# Altium schematic sheet ESP-WROOM32.SchDoc(ESP-WROOM32) (continued)

## Library data of the parts
*1 = ESP32-WROOM-32E-N4: RF TXRX MOD BT WIFI TH SMD; Manufacturer: Espressif Systems; Max Supply Voltage: 3.6V; Min Supply Voltage: 3V; Operating Supply Voltage: 3.3V; Category: System on Chip (SoC); Component Kind: Standard; ComponentLink1Description: https://datasheet.octopart.com:443/ESP32-WROOM-32E-N4-Espressif-Systems-datasheet-182247776.pdf?src-supplier=IHS; ComponentLink1URL: https://datasheet.octopart.com:443/ESP32-WROOM-32E-N4-Espressif-Systems-datasheet-182247776.pdf?src-supplier=IHS; ComponentLink2Description: https://datasheet.octopart.com:443/ESP32-WROOM-32E-N4-Espressif-Systems-datasheet-188867343.pdf?src-supplier=TME; ComponentLink2URL: https://datasheet.octopart.com:443/ESP32-WROOM-32E-N4-Espressif-Systems-datasheet-188867343.pdf?src-supplier=TME; ComponentLink3Description: https://datasheet.octopart.com:443/ESP32-WROOM-32E-N4-Espressif-Systems-datasheet-165560559.pdf?src-supplier=TME; ComponentLink3URL: https://datasheet.octopart.com:443/ESP32-WROOM-32E-N4-Espressif-Systems-datasheet-165560559.pdf?src-supplier=TME; ComponentLink4Description: https://datasheet.octopart.com:443/ESP32-WROOM-32E-N4-Espressif-Systems-datasheet-151884363.pdf?src-supplier=Future+Electronics; ComponentLink4URL: https://datasheet.octopart.com:443/ESP32-WROOM-32E-N4-Espressif-Systems-datasheet-151884363.pdf?src-supplier=Future+Electronics; Height - Seated (Max): 3.25mm; LCSC part number: C701341; Length: 25.5mm; Manufacturer Part Number: ESP32-WROOM-32E-N4; Max Operating Temperature: 85°C; Min Operating Temperature: -40°C; Number of Terminals: 38; Pricing: 1=4.84, 10=4.189, 25=3.9612, 100=3.649, 250=3.46184 (USD); RoHS: Compliant; Stock: 16186; Supplier: DigiKey; Supplier Part Number: 1965-ESP32-WROOM-32E-N4CT-ND; Terminal Pitch: 1.27mm; Width: 18mm; Library Name: Librayryschematic.SchLib
C1 C2 C5-C8 C12 = C1206C104K5JACAUTO: CAP CER 0.1UF 50V U2J 1206; LSCS PART NUMBER: C2264143; Manufacturer: Samsung Electro-Mechanics; Manufacturer Part Number: CL05B104KB5NNWC; Dielectric Material: Ceramic; Value: 100nF; Case/Package: 1206; Category: Ceramic Capacitors; China RoHS: Compliant; Component Kind: Standard; ComponentLink1Description: https://datasheet.octopart.com:443/CL05B104KB5NNWC-Samsung-Electro-Mechanics-datasheet-182018729.pdf?src-supplier=IHS; ComponentLink1URL: https://datasheet.octopart.com:443/CL05B104KB5NNWC-Samsung-Electro-Mechanics-datasheet-182018729.pdf?src-supplier=IHS; ComponentLink2Description: https://datasheet.octopart.com:443/C1206C104K5JACAUTO-KEMET-datasheet-175574894.pdf?src-supplier=IHS; ComponentLink2URL: https://datasheet.octopart.com:443/C1206C104K5JACAUTO-KEMET-datasheet-175574894.pdf?src-supplier=IHS; ComponentLink3Description: https://datasheet.octopart.com:443/C1206C104K5JACAUTO-KEMET-datasheet-138896532.pdf?src-supplier=Upverter; ComponentLink3URL: https://datasheet.octopart.com:443/C1206C104K5JACAUTO-KEMET-datasheet-138896532.pdf?src-supplier=Upverter; ComponentLink4Description: https://datasheet.octopart.com:443/C1206C104K5JACAUTO-KEMET-datasheet-141421995.pdf?src-supplier=Farnell; ComponentLink4URL: https://datasheet.octopart.com:443/C1206C104K5JACAUTO-KEMET-datasheet-141421995.pdf?src-supplier=Farnell; ComponentLink5Description: https://datasheet.octopart.com:443/C1206C104K5JACAUTO-KEMET-datasheet-110724407.pdf?src-supplier=RS+(Formerly+Allied+Electronics); ComponentLink5URL: https://datasheet.octopart.com:443/C1206C104K5JACAUTO-KEMET-datasheet-110724407.pdf?src-supplier=RS+(Formerly+Allied+Electronics); Dielectric: U2J; Height: 1.2mm; LCSC part number: C7229230; Length: 3.2mm; Lifecycle Status: Production; Material: Ceramic; Max Operating Temperature: 125°C; Min Operating Temperature: -55°C; Mounting Technology: Surface Mount; Number of Terminals: 2; Pricing: 1=0.1, 10=0.054, 50=0.037, 100=0.0319, 500=0.02338, 1000=0.02075, 2500=0.018, 5000=0.01634 (USD); REACH SVHC: Yes; RoHS: Compliant; Schedule B: 8532240020, 8532240020|8532240020|8532240020|8532240020|8532240020; Stock: 89724; Supplier: DigiKey; Supplier Part Number: 1276-CL05B104KB5NNWCCT-ND; Voltage Rating: 50V; Voltage Rating (DC): 50V; Width: 1.6mm; Library Name: Librayryschematic.SchLib
C3 C9 C10 = CC1206MKX5R6BB226: CAP CER 22UF 10V X5R 1206; LSCS PART NUMBER: C723738; Manufacturer: Murata; Manufacturer Lifecycle Status: IN PRODUCTION; Manufacturer Part Number: GRM158R61A226ME15D; Dielectric Material: Ceramic; Value: 22µF; Case Code (Imperial): 1206; Case Code (Metric): 3216; Case/Package: 0402; Category: Ceramic Capacitors; China RoHS: Compliant; Component Kind: Standard; ComponentLink1Description: https://datasheet.octopart.com:443/GRM158R61A226ME15D-Murata-datasheet-165579666.pdf?src-supplier=TME; ComponentLink1URL: https://datasheet.octopart.com:443/GRM158R61A226ME15D-Murata-datasheet-165579666.pdf?src-supplier=TME; ComponentLink2Description: https://datasheet.octopart.com/GRM158R61A226ME15D-Murata-datasheet-12515295.pdf; ComponentLink2URL: https://datasheet.octopart.com/GRM158R61A226ME15D-Murata-datasheet-12515295.pdf; ComponentLink3Description: https://datasheet.octopart.com/GRM158R61A226ME15D-Murata-datasheet-12510076.pdf; ComponentLink3URL: https://datasheet.octopart.com/GRM158R61A226ME15D-Murata-datasheet-12510076.pdf; ComponentLink4Description: https://datasheet.octopart.com/GRM158R61A226ME15D-Murata-datasheet-11978611.pdf; ComponentLink4URL: https://datasheet.octopart.com/GRM158R61A226ME15D-Murata-datasheet-11978611.pdf; ComponentLink5Description: https://datasheet.octopart.com/GRM158R61A226ME15D-Murata-datasheet-10025737.pdf; ComponentLink5URL: https://datasheet.octopart.com/GRM158R61A226ME15D-Murata-datasheet-10025737.pdf; ComponentLink6Description: https://datasheet.octopart.com/GRM158R61A226ME15D-Murata-datasheet-8819587.pdf; ComponentLink6URL: https://datasheet.octopart.com/GRM158R61A226ME15D-Murata-datasheet-8819587.pdf; ComponentLink7Description: http://sigma.octopart.com:80/reach_statement/Murata-company-16.pdf?src-supplier=Compliance+Statements; ComponentLink7URL: http://sigma.octopart.com:80/reach_statement/Murata-company-16.pdf?src-supplier=Compliance+Statements; ComponentLink8Description: https://datasheet.octopart.com:443/CC1206MKX5R6BB226-Yageo-datasheet-170350636.pdf?src-supplier=IHS; ComponentLink8URL: https://datasheet.octopart.com:443/CC1206MKX5R6BB226-Yageo-datasheet-170350636.pdf?src-supplier=IHS; ComponentLink9Description: https://datasheet.octopart.com:443/CC1206MKX5R6BB226-Yageo-datasheet-182965674.pdf?src-supplier=Farnell; ComponentLink9URL: https://datasheet.octopart.com:443/CC1206MKX5R6BB226-Yageo-datasheet-182965674.pdf?src-supplier=Farnell; ComponentLink10Description: https://datasheet.octopart.com:443/CC1206MKX5R6BB226-Yageo-Group-datasheet-145016144.pdf?src-supplier=ODG+(Origin+Data+Global); ComponentLink10URL: https://datasheet.octopart.com:443/CC1206MKX5R6BB226-Yageo-Group-datasheet-145016144.pdf?src-supplier=ODG+(Origin+Data+Global); ComponentLink11Description: https://datasheet.octopart.com:443/CC1206MKX5R6BB226-Yageo-datasheet-137700085.pdf?src-supplier=Future+Electronics; ComponentLink11URL: https://datasheet.octopart.com:443/CC1206MKX5R6BB226-Yageo-datasheet-137700085.pdf?src-supplier=Future+Electronics; ComponentLink12Description: https://datasheet.octopart.com:443/CC1206MKX5R6BB226-Yageo-datasheet-82288757.pdf?src-supplier=Future+Electronics; ComponentLink12URL: https://datasheet.octopart.com:443/CC1206MKX5R6BB226-Yageo-datasheet-82288757.pdf?src-supplier=Future+Electronics; ComponentLink13Description: https://datasheet.octopart.com:443/CC1206MKX5R6BB226-Yageo-datasheet-78855685.pdf?src-supplier=Future+Electronics; ComponentLink13URL: https://datasheet.octopart.com:443/CC1206MKX5R6BB226-Yageo-datasheet-78855685.pdf?src-supplier=Future+Electronics; ComponentLink14Description: https://datasheet.octopart.com:443/CC1206MKX5R6BB226-Yageo-datasheet-62336348.pdf?src-supplier=Future+Electronics; ComponentLink14URL: https://datasheet.octopart.com:443/CC1206MKX5R6BB226-Yageo-datasheet-62336348.pdf?src-supplier=Future+Electronics; ComponentLink15Description: https://datasheet.octopart.com:443/CC1206MKX5R6BB226-Yageo-datasheet-68298032.pdf?src-supplier=Future+Electronics; ComponentLink15URL: https://datasheet.octopart.com:443/CC1206MKX5R6BB226-Yageo-datasheet-68298032.pdf?src-supplier=Future+Electronics; ComponentLink16Description: https://datasheet.octopart.com/CC1206MKX5R6BB226-Yageo-datasheet-86397281.pdf; ComponentLink16URL: https://datasheet.octopart.com/CC1206MKX5R6BB226-Yageo-datasheet-86397281.pdf; ComponentLink17Description: http://sigma.octopart.com:80/rohs_statement/Yageo-company-2.pdf?src-supplier=Compliance+Statements; ComponentLink17URL: http://sigma.octopart.com:80/rohs_statement/Yageo-company-2.pdf?src-supplier=Compliance+Statements; ComponentLink18Description: http://sigma.octopart.com:80/conflict_mineral_statement/Yageo-company-3.pdf?src-supplier=Compliance+Statements; ComponentLink18URL: http://sigma.octopart.com:80/conflict_mineral_statement/Yageo-company-3.pdf?src-supplier=Compliance+Statements; Dielectric: X5R; Halogen Free: Halogen Free; Height: 800µm; LCSC part number: C3845593; Lead Free: Lead Free; Length: 1mm; Lifecycle Status: Production; Material: Ceramic; Max Operating Temperature: 85°C; Min Operating Temperature: -55°C; Mounting Technology: Surface Mount; Number of Terminals: 2; Pricing: 1=0.41, 10=0.247, 50=0.1828, 100=0.1632, 500=0.1301, 1000=0.1199, 2500=0.10912 (USD); REACH SVHC: Yes; RoHS: Compliant; Schedule B: 8532240020, 8532240020|8532240020|8532240020|8532240020|8532240020; Stock: 340623; Supplier: DigiKey; Supplier Part Number: 490-GRM158R61A226ME15DCT-ND; Thickness: 800µm; Tolerance: 20%; Voltage Rating: 10V; Voltage Rating (DC): 10V; Width: 500µm; Library Name: Librayryschematic.SchLib
C4 C11 = CC1206KKX5R6BB106: CAP CER 10UF 10V X5R 1206; LSCS PART NUMBER: C527332; Manufacturer: Yageo Group; Manufacturer Part Number: CC0402MRX5R6BB106; Dielectric Material: Ceramic; Tolerance: 10%; Value: 10µF; Case Code (Imperial): 1206; Case Code (Metric): 3216; Case/Package: 0402; Category: Ceramic Capacitors; China RoHS: Compliant; Component Kind: Standard; ComponentLink1Description: https://datasheet.octopart.com:443/CC0402MRX5R6BB106-Yageo-datasheet-170350636.pdf?src-supplier=IHS; ComponentLink1URL: https://datasheet.octopart.com:443/CC0402MRX5R6BB106-Yageo-datasheet-170350636.pdf?src-supplier=IHS; ComponentLink2Description: https://datasheet.octopart.com:443/CC0402MRX5R6BB106-Yageo-Group-datasheet-189192896.pdf?src-supplier=TME; ComponentLink2URL: https://datasheet.octopart.com:443/CC0402MRX5R6BB106-Yageo-Group-datasheet-189192896.pdf?src-supplier=TME; ComponentLink3Description: https://datasheet.octopart.com:443/CC0402MRX5R6BB106-Yageo-datasheet-182029486.pdf?src-supplier=Newark; ComponentLink3URL: https://datasheet.octopart.com:443/CC0402MRX5R6BB106-Yageo-datasheet-182029486.pdf?src-supplier=Newark; ComponentLink4Description: https://datasheet.octopart.com:443/CC0402MRX5R6BB106-Yageo-datasheet-158238148.pdf?src-supplier=Newark; ComponentLink4URL: https://datasheet.octopart.com:443/CC0402MRX5R6BB106-Yageo-datasheet-158238148.pdf?src-supplier=Newark; ComponentLink5Description: https://datasheet.octopart.com/CC0402MRX5R6BB106-Yageo-datasheet-86397281.pdf; ComponentLink5URL: https://datasheet.octopart.com/CC0402MRX5R6BB106-Yageo-datasheet-86397281.pdf; ComponentLink6Description: https://datasheet.octopart.com:443/CC0402MRX5R6BB106-Yageo-datasheet-175963154.pdf?src-supplier=element14+APAC; ComponentLink6URL: https://datasheet.octopart.com:443/CC0402MRX5R6BB106-Yageo-datasheet-175963154.pdf?src-supplier=element14+APAC; ComponentLink7Description: https://datasheet.octopart.com:443/CC0402MRX5R6BB106-Yageo-Group-datasheet-145016144.pdf?src-supplier=ODG+(Origin+Data+Global); ComponentLink7URL: https://datasheet.octopart.com:443/CC0402MRX5R6BB106-Yageo-Group-datasheet-145016144.pdf?src-supplier=ODG+(Origin+Data+Global); ComponentLink8Description: https://datasheet.octopart.com:443/CC0402MRX5R6BB106-Yageo-datasheet-62067349.pdf?src-supplier=Future+Electronics; ComponentLink8URL: https://datasheet.octopart.com:443/CC0402MRX5R6BB106-Yageo-datasheet-62067349.pdf?src-supplier=Future+Electronics; ComponentLink9Description: http://sigma.octopart.com:80/conflict_mineral_statement/Yageo-company-3.pdf?src-supplier=Compliance+Statements; ComponentLink9URL: http://sigma.octopart.com:80/conflict_mineral_statement/Yageo-company-3.pdf?src-supplier=Compliance+Statements; ComponentLink10Description: http://sigma.octopart.com:80/rohs_statement/Yageo-company-2.pdf?src-supplier=Compliance+Statements; ComponentLink10URL: http://sigma.octopart.com:80/rohs_statement/Yageo-company-2.pdf?src-supplier=Compliance+Statements; ComponentLink11Description: https://datasheet.octopart.com:443/CC1206KKX5R6BB106-Yageo-datasheet-170350636.pdf?src-supplier=IHS; ComponentLink11URL: https://datasheet.octopart.com:443/CC1206KKX5R6BB106-Yageo-datasheet-170350636.pdf?src-supplier=IHS; ComponentLink12Description: https://datasheet.octopart.com:443/CC1206KKX5R6BB106-Yageo-datasheet-10911834.pdf?src-supplier=element14+APAC; ComponentLink12URL: https://datasheet.octopart.com:443/CC1206KKX5R6BB106-Yageo-datasheet-10911834.pdf?src-supplier=element14+APAC; ComponentLink13Description: https://datasheet.octopart.com:443/CC1206KKX5R6BB106-Yageo-datasheet-62067349.pdf?src-supplier=element14+APAC; ComponentLink13URL: https://datasheet.octopart.com:443/CC1206KKX5R6BB106-Yageo-datasheet-62067349.pdf?src-supplier=element14+APAC; ComponentLink14Description: https://datasheet.octopart.com:443/CC1206KKX5R6BB106-Yageo-datasheet-181970622.pdf?src-supplier=Farnell; ComponentLink14URL: https://datasheet.octopart.com:443/CC1206KKX5R6BB106-Yageo-datasheet-181970622.pdf?src-supplier=Farnell; ComponentLink15Description: https://datasheet.octopart.com:443/CC1206KKX5R6BB106-Yageo-datasheet-181970623.pdf?src-supplier=Farnell; ComponentLink15URL: https://datasheet.octopart.com:443/CC1206KKX5R6BB106-Yageo-datasheet-181970623.pdf?src-supplier=Farnell; ComponentLink16Description: https://datasheet.octopart.com:443/CC1206KKX5R6BB106-Yageo-datasheet-175963154.pdf?src-supplier=JRH+Electronics; ComponentLink16URL: https://datasheet.octopart.com:443/CC1206KKX5R6BB106-Yageo-datasheet-175963154.pdf?src-supplier=JRH+Electronics; ComponentLink17Description: https://datasheet.octopart.com:443/CC1206KKX5R6BB106-Yageo-datasheet-158238148.pdf?src-supplier=Newark; ComponentLink17URL: https://datasheet.octopart.com:443/CC1206KKX5R6BB106-Yageo-datasheet-158238148.pdf?src-supplier=Newark; ComponentLink18Description: https://datasheet.octopart.com:443/CC1206KKX5R6BB106-Yageo-datasheet-8359971.pdf?src-supplier=Newark; ComponentLink18URL: https://datasheet.octopart.com:443/CC1206KKX5R6BB106-Yageo-datasheet-8359971.pdf?src-supplier=Newark; ComponentLink19Description: https://datasheet.octopart.com/CC1206KKX5R6BB106-Yageo-datasheet-86397281.pdf; ComponentLink19URL: https://datasheet.octopart.com/CC1206KKX5R6BB106-Yageo-datasheet-86397281.pdf; ComponentLink20Description: https://datasheet.octopart.com:443/CC1206KKX5R6BB106-Yageo-datasheet-8324442.pdf?src-supplier=Future+Electronics; ComponentLink20URL: https://datasheet.octopart.com:443/CC1206KKX5R6BB106-Yageo-datasheet-8324442.pdf?src-supplier=Future+Electronics; ComponentLink21Description: https://datasheet.octopart.com:443/CC1206KKX5R6BB106-Yageo-datasheet-137875056.pdf?src-supplier=Future+Electronics; ComponentLink21URL: https://datasheet.octopart.com:443/CC1206KKX5R6BB106-Yageo-datasheet-137875056.pdf?src-supplier=Future+Electronics; ComponentLink22Description: https://datasheet.octopart.com:443/CC1206KKX5R6BB106-Yageo-datasheet-59875459.pdf?src-supplier=Future+Electronics; ComponentLink22URL: https://datasheet.octopart.com:443/CC1206KKX5R6BB106-Yageo-datasheet-59875459.pdf?src-supplier=Future+Electronics; ComponentLink23Description: https://datasheet.octopart.com:443/CC1206KKX5R6BB106-Yageo-datasheet-27626583.pdf?src-supplier=Future+Electronics; ComponentLink23URL: https://datasheet.octopart.com:443/CC1206KKX5R6BB106-Yageo-datasheet-27626583.pdf?src-supplier=Future+Electronics; ComponentLink24Description: https://datasheet.octopart.com:443/CC1206KKX5R6BB106-Yageo-datasheet-24580494.pdf?src-supplier=Future+Electronics; ComponentLink24URL: https://datasheet.octopart.com:443/CC1206KKX5R6BB106-Yageo-datasheet-24580494.pdf?src-supplier=Future+Electronics; ComponentLink25Description: https://datasheet.octopart.com:443/CC1206KKX5R6BB106-Yageo-datasheet-14389981.pdf?src-supplier=Future+Electronics; ComponentLink25URL: https://datasheet.octopart.com:443/CC1206KKX5R6BB106-Yageo-datasheet-14389981.pdf?src-supplier=Future+Electronics; ComponentLink26Description: https://datasheet.octopart.com:443/CC1206KKX5R6BB106-Yageo-datasheet-149070021.pdf?src-supplier=TME; ComponentLink26URL: https://datasheet.octopart.com:443/CC1206KKX5R6BB106-Yageo-datasheet-149070021.pdf?src-supplier=TME; ComponentLink27Description: https://datasheet.octopart.com:443/CC1206KKX5R6BB106-Yageo-Group-datasheet-145016144.pdf?src-supplier=ODG+(Origin+Data+Global); ComponentLink27URL: https://datasheet.octopart.com:443/CC1206KKX5R6BB106-Yageo-Group-datasheet-145016144.pdf?src-supplier=ODG+(Origin+Data+Global); Composition: Ceramic; Depth: 1.6mm; Dielectric: X5R; Halogen Free: Halogen Free; Height: 500µm; LCSC PART NUMBER: C962143; Lead Free: Lead Free; Length: 1mm; Lifecycle Status: Production; Max Operating Temperature: 85°C; Min Operating Temperature: -55°C; Mounting Technology: Surface Mount; Number of Terminals: 2; Pins: 2; Pricing: 1=0.21, 10=0.121, 50=0.0866, 100=0.076, 500=0.05824, 1000=0.05277, 2500=0.04701, 5000=0.04354 (USD); Radiation Hardening: No; REACH SVHC: Yes; RoHS: Compliant; Stock: 59624; Supplier: DigiKey; Supplier Part Number: 13-CC0402MRX5R6BB106CT-ND; Termination: SMD/SMT; Thickness: 1.8034mm; Voltage Rating: 10V; Voltage Rating (AC): 10V; Voltage Rating (DC): 10V; Weight: 16.187578mg; Width: 500µm; Library Name: Librayryschematic.SchLib
CP1 = CP2102N-A02-GQFN28: USB Bridge, USB to UART USB 2.0 UART Interface 28-QFN (5x5); Manufacturer: Silicon Labs; Manufacturer Lifecycle Status: FULL PRODUCTION; Data Rate: 1.5Mbps; Max Junction Temperature (Tj): 105°C; Max Supply Voltage: 3.6V; Min Supply Voltage: 3V; Number of Digital IO: 7; Number of Ports: 1; Operating Supply Voltage: 3.3V; USB Standard: USB 2.0; Ambient Temperature Range High: 85°C; Category: USB Interface ICs; China RoHS: Compliant; Component Kind: Standard; ComponentLink1Description: https://datasheet.octopart.com:443/CP2102N-A02-GQFN28-Silicon-Labs-datasheet-138898368.pdf?src-supplier=Augswan; ComponentLink1URL: https://datasheet.octopart.com:443/CP2102N-A02-GQFN28-Silicon-Labs-datasheet-138898368.pdf?src-supplier=Augswan; ComponentLink2Description: https://datasheet.octopart.com:443/CP2102N-A02-GQFN28-Silicon-Labs-datasheet-166051788.pdf?src-supplier=IHS; ComponentLink2URL: https://datasheet.octopart.com:443/CP2102N-A02-GQFN28-Silicon-Labs-datasheet-166051788.pdf?src-supplier=IHS; Height: 800µm; Height - Seated (Max): 800µm; Interface: UART; LCSC PART NUMBER: C964632; Length: 5mm; Lifecycle Status: Production; Manufacturer Part Number: CP2102N-A02-GQFN28; Max Operating Temperature: 85°C; Min Operating Temperature: -40°C; Number of Terminals: 28; Pricing: 1=4.32, 10=3.275, 73=2.78205, 146=2.66534, 292=2.56928, 511=2.50429, 1022=2.43673, 2555=2.36542 (USD); REACH SVHC: Yes; RoHS: Compliant; Stock: 1307; Supplier: DigiKey; Supplier Part Number: 336-5889-ND; Terminal Pitch: 500µm; Width: 5mm; Library Name: Librayryschematic.SchLib
D1-D3 = AQ3045-01ETG: TVS DIODE 5.3VWM 12VC SOD882; Manufacturer: Littelfuse; Breakdown Voltage: 7.8V; Clamping Voltage: 12V; Leakage Current: 100nA; Max Breakdown Voltage: 9V; Min Breakdown Voltage: 6.8V; Number of Channels: 1; Operating Supply Voltage: 5.3V; Peak Pulse Power: 40W; Polarity: Bidirectional; Category: TVS Diodes; Component Kind: Standard; ComponentLink1Description: https://datasheet.octopart.com:443/AQ3045-01ETG-Littelfuse-datasheet-180606588.pdf?src-supplier=IHS; ComponentLink1URL: https://datasheet.octopart.com:443/AQ3045-01ETG-Littelfuse-datasheet-180606588.pdf?src-supplier=IHS; ComponentLink2Description: https://datasheet.octopart.com:443/AQ3045-01ETG-Littelfuse-datasheet-183003566.pdf?src-supplier=JRH+Electronics; ComponentLink2URL: https://datasheet.octopart.com:443/AQ3045-01ETG-Littelfuse-datasheet-183003566.pdf?src-supplier=JRH+Electronics; ComponentLink3Description: https://datasheet.octopart.com:443/AQ3045-01ETG-Littelfuse-datasheet-140480283.pdf?src-supplier=ODG+(Origin+Data+Global); ComponentLink3URL: https://datasheet.octopart.com:443/AQ3045-01ETG-Littelfuse-datasheet-140480283.pdf?src-supplier=ODG+(Origin+Data+Global); ComponentLink4Description: https://datasheet.octopart.com:443/AQ3045-01ETG-Littelfuse-datasheet-101609968.pdf?src-supplier=Farnell; ComponentLink4URL: https://datasheet.octopart.com:443/AQ3045-01ETG-Littelfuse-datasheet-101609968.pdf?src-supplier=Farnell; ComponentLink5Description: https://datasheet.octopart.com:443/AQ3045-01ETG-Littelfuse-datasheet-170904929.pdf?src-supplier=Future+Electronics; ComponentLink5URL: https://datasheet.octopart.com:443/AQ3045-01ETG-Littelfuse-datasheet-170904929.pdf?src-supplier=Future+Electronics; LCSC PART NUMBER: C3710326; Lifecycle Status: Production; Manufacturer Part Number: AQ3045-01ETG; Max Operating Temperature: 150°C; Min Operating Temperature: -40°C; Mounting Technology: Surface Mount; Number of Elements: 1; Number of Terminals: 2; Packaging: Cut Tape; Peak Reverse Current: 100nA; Pricing: 10000=0.1196 (USD); REACH SVHC: Yes; Reverse Standoff Voltage: 5.3V; RoHS: Compliant; Stock: 250000; Supplier: Arrow Electronics; Supplier Part Number: AQ3045-01ETG; Library Name: Librayryschematic.SchLib
D4 = LTST-C170GKT: LED GREEN CLEAR SMD; Manufacturer: Lite-On; Current: 4A; Dominant Wavelength: 569nm; Forward Current: 30mA; Forward Voltage: 2.6V; Lens Color: Clear, Colorless; Luminous Intensity: 6mcd; Number of Circuits: 1; Peak Wavelength: 565nm; Power Dissipation: 100mW; Reverse Voltage: 5V; Shape: Rectangular; Test Current: 10mA; Viewing Angle: 130°; Case Code (Imperial): 0805; Case Code (Metric): 2012; Case/Package: 0805; Category: LEDs; China RoHS: Compliant; Color: Green; Component Kind: Standard; ComponentLink1Description: https://datasheet.octopart.com:443/LTST-C170GKT-Lite-On-datasheet-180605137.pdf?src-supplier=IHS; ComponentLink1URL: https://datasheet.octopart.com:443/LTST-C170GKT-Lite-On-datasheet-180605137.pdf?src-supplier=IHS; ComponentLink2Description: https://datasheet.octopart.com:443/LTST-C170GKT-Lite-On-datasheet-141439001.pdf?src-supplier=Upverter; ComponentLink2URL: https://datasheet.octopart.com:443/LTST-C170GKT-Lite-On-datasheet-141439001.pdf?src-supplier=Upverter; ComponentLink3Description: https://datasheet.octopart.com:443/LTST-C170GKT-Lite-On-datasheet-159307.pdf?src-supplier=Arrow+Electronics; ComponentLink3URL: https://datasheet.octopart.com:443/LTST-C170GKT-Lite-On-datasheet-159307.pdf?src-supplier=Arrow+Electronics; ComponentLink4Description: https://datasheet.octopart.com:443/LTST-C170GKT-Lite-On-datasheet-8330158.pdf?src-supplier=TME; ComponentLink4URL: https://datasheet.octopart.com:443/LTST-C170GKT-Lite-On-datasheet-8330158.pdf?src-supplier=TME; ComponentLink5Description: https://datasheet.octopart.com:443/LTST-C170GKT-Lite-On-datasheet-26684672.pdf?src-supplier=Future+Electronics; ComponentLink5URL: https://datasheet.octopart.com:443/LTST-C170GKT-Lite-On-datasheet-26684672.pdf?src-supplier=Future+Electronics; ComponentLink6Description: https://datasheet.octopart.com:443/LTST-C170GKT-Lite-On-datasheet-59241.pdf?src-supplier=DigiKey; ComponentLink6URL: https://datasheet.octopart.com:443/LTST-C170GKT-Lite-On-datasheet-59241.pdf?src-supplier=DigiKey; ComponentLink7Description: https://datasheet.octopart.com:443/LTST-C170GKT-Lite-On-datasheet-14174986.pdf?src-supplier=iiiC; ComponentLink7URL: https://datasheet.octopart.com:443/LTST-C170GKT-Lite-On-datasheet-14174986.pdf?src-supplier=iiiC; Depth: 1.25mm; Height: 1.1mm; Illumination Color: Green; LCSC PART NUMBER: C125090; Lead Free: Lead Free; Length: 2mm; Lens Transparency: Clear; Lifecycle Status: Production; Manufacturer Part Number: LTST-C170GKT; Max Operating Temperature: 85°C; Min Operating Temperature: -55°C; Mounting Technology: Surface Mount; Number of Elements: 1; Number of LEDs: 1; Number of Terminals: 2; Packaging: Tape and Reel; Pins: 2; Power Rating: 100mW; Pricing: 1=0.1, 10=0.066, 100=0.0453, 500=0.03552, 1000=0.03224 (USD); Radiation Hardening: No; REACH SVHC: Yes; RoHS: Compliant; Schedule B: 8541402000, 8541402000|8541402000|8541402000|8541402000|8541402000|8541402000|8541402000|8541402000|8541402000|8541402000|8541402000|8541402000|8541402000|8541402000|8541402000|8541402000|8541402000|8541402000|8541402000|8541402000|8541402000|8541402000|8541402000|85; Stock: 1226220; Supplier: DigiKey; Supplier Part Number: 160-1179-1-ND; Terminal Pitch: 2.1mm; Voltage: 5V; Wavelength: 569nm; Width: 1.25mm; Library Name: Librayryschematic.SchLib
D5 = BAT760Q-7: DIODE SCHOTTKY 30V 1A SOD323; Manufacturer: Diodes Inc.; Max Forward Surge Current (Ifsm): 5.5A; Max Power Dissipation: 235mW; Number of Elements: 1; Average Rectified Current: 1A; Category: Schottky Diodes; China RoHS: Compliant; Component Kind: Standard; ComponentLink1Description: https://datasheet.octopart.com:443/BAT760Q-7-Diodes-Inc.-datasheet-151209907.pdf?src-supplier=IHS; ComponentLink1URL: https://datasheet.octopart.com:443/BAT760Q-7-Diodes-Inc.-datasheet-151209907.pdf?src-supplier=IHS; ComponentLink2Description: https://sigma.octopart.com:443/138810740/technical_drawing/Diodes-Inc.-BAT760Q-7.pdf?src-supplier=Diodes+Inc+SCT; ComponentLink2URL: https://sigma.octopart.com:443/138810740/technical_drawing/Diodes-Inc.-BAT760Q-7.pdf?src-supplier=Diodes+Inc+SCT; lcsc part number: C3759252; Lifecycle Status: Production; Manufacturer Part Number: BAT760Q-7; Max Operating Temperature: 150°C; Max Output current: 1A; Max Reverse Voltage (DC): 30V; Min Operating Temperature: -65°C; Mounting Technology: Surface Mount; Number of Terminals: 2; Pricing: 1=0.3, 10=0.205, 100=0.1688, 500=0.12602, 1000=0.11219 (USD); REACH SVHC: Yes; Reverse Standoff Voltage: 30V; RoHS: Compliant; Schedule B: 8541100080, 8541100080|8541100080|8541100080|8541100080|8541100080; Stock: 16229; Supplier: DigiKey; Supplier Part Number: BAT760Q-7DICT-ND; Library Name: Librayryschematic.SchLib
D6 = BH1750FVI-TR: SENSOR OPT 560NM AMBIENT 6WSOF; Manufacturer: ROHM; Clock Frequency: 400kHz; Interface: I2C, Serial; Max Power Dissipation: 260mW; Max Supply Voltage: 3.6V; Min Supply Voltage: 2.4V; Number of Circuits: 1; Operating Supply Current: 120µA; Operating Supply Voltage: 3V; Output Type: Digital, I2C; Sensing Method: Optical; Type: Integrated Circuit (IC); Case/Package: SMD/SMT; Category: Optical Sensors; Component Kind: Standard; ComponentLink1Description: https://sigma.octopart.com:443/169791153/technical_drawing/ROHM-BH1750FVI-TR.pdf?src-supplier=Upverter; ComponentLink1URL: https://sigma.octopart.com:443/169791153/technical_drawing/ROHM-BH1750FVI-TR.pdf?src-supplier=Upverter; ComponentLink2Description: https://datasheet.octopart.com:443/BH1750FVI-TR-Rohm-datasheet-141422541.pdf?src-supplier=Upverter; ComponentLink2URL: https://datasheet.octopart.com:443/BH1750FVI-TR-Rohm-datasheet-141422541.pdf?src-supplier=Upverter; ComponentLink3Description: https://datasheet.octopart.com:443/BH1750FVI-TR-ROHM-datasheet-169791149.pdf?src-supplier=Upverter; ComponentLink3URL: https://datasheet.octopart.com:443/BH1750FVI-TR-ROHM-datasheet-169791149.pdf?src-supplier=Upverter; ComponentLink4Description: https://datasheet.octopart.com:443/BH1750FVI-TR-Rohm-datasheet-25365051.pdf?src-supplier=Newark; ComponentLink4URL: https://datasheet.octopart.com:443/BH1750FVI-TR-Rohm-datasheet-25365051.pdf?src-supplier=Newark; ComponentLink5Description: https://datasheet.octopart.com:443/BH1750FVI-TR-Rohm-datasheet-8331172.pdf?src-supplier=_legacy+Avnet; ComponentLink5URL: https://datasheet.octopart.com:443/BH1750FVI-TR-Rohm-datasheet-8331172.pdf?src-supplier=_legacy+Avnet; ComponentLink6Description: https://datasheet.octopart.com:443/BH1750FVI-TR-Rohm-datasheet-145014626.pdf?src-supplier=Future+Electronics; ComponentLink6URL: https://datasheet.octopart.com:443/BH1750FVI-TR-Rohm-datasheet-145014626.pdf?src-supplier=Future+Electronics; ComponentLink7Description: https://datasheet.octopart.com:443/BH1750FVI-TR-Rohm-datasheet-14434783.pdf?src-supplier=Factory+Futures; ComponentLink7URL: https://datasheet.octopart.com:443/BH1750FVI-TR-Rohm-datasheet-14434783.pdf?src-supplier=Factory+Futures; Contact Plating: Copper, Tin; Height: 750µm; Height - Seated (Max): 750µm; LCSC PART NUMBER: C78960; Lead Free: Lead Free; Length: 2.6mm; Lifecycle Status: Obsolete; Manufacturer Part Number: BH1750FVI-TR; Max Operating Temperature: 85°C; Min Operating Temperature: -40°C; Mounting Technology: Surface Mount; Number of Terminals: 6; Pins: 6; Pricing: 1=3.29, 5=2.934, 10=2.804, 25=2.648, 50=2.542, 100=2.4447, 500=2.24896, 1000=2.18484 (USD); Radiation Hardening: No; REACH SVHC: Yes; RoHS: Compliant; Schedule B: 8541409500; Stock: 3509; Supplier: DigiKey; Supplier Part Number: BH1750FVICT-ND; Terminal Pitch: 500µm; Wavelength: 560nm; Width: 1.6mm; Library Name: Librayryschematic.SchLib
J1 = 2171790001: CONN RCP USB2.0 TYP C 24P SMD RA; Manufacturer: Molex; Manufacturer Part Number: 217179-0001; Contact Resistance: 50mΩ; Current Rating: 1.25A; Dielectric Material: Polyamide; Gender: Receptacle; Insulation Resistance: 100MΩ; Number of Contacts: 16; Number of Ports: 1; Voltage Rating: 30V; Category: USB Connectors; Component Kind: Standard; ComponentLink1Description: https://datasheet.octopart.com:443/217179-0001-Molex-datasheet-158871109.pdf?src-supplier=ODG+(Origin+Data+Global); ComponentLink1URL: https://datasheet.octopart.com:443/217179-0001-Molex-datasheet-158871109.pdf?src-supplier=ODG+(Origin+Data+Global); ComponentLink2Description: https://sigma.octopart.com:443/158871110/technical_drawing/Molex-217179-0001.pdf?src-supplier=Upverter; ComponentLink2URL: https://sigma.octopart.com:443/158871110/technical_drawing/Molex-217179-0001.pdf?src-supplier=Upverter; ComponentLink3Description: https://datasheet.octopart.com:443/217179-0001-Molex-datasheet-164573281.pdf?src-supplier=RS+(Formerly+Allied+Electronics); ComponentLink3URL: https://datasheet.octopart.com:443/217179-0001-Molex-datasheet-164573281.pdf?src-supplier=RS+(Formerly+Allied+Electronics); ComponentLink4Description: http://sigma.octopart.com:80/conflict_mineral_statement/Molex-company-72.pdf?src-supplier=Compliance+Statements; ComponentLink4URL: http://sigma.octopart.com:80/conflict_mineral_statement/Molex-company-72.pdf?src-supplier=Compliance+Statements; ComponentLink5Description: http://sigma.octopart.com:80/rohs_statement/Molex-company-73.pdf?src-supplier=Compliance+Statements; ComponentLink5URL: http://sigma.octopart.com:80/rohs_statement/Molex-company-73.pdf?src-supplier=Compliance+Statements; ComponentLink6Description: http://sigma.octopart.com:80/reach_statement/Molex-company-74.pdf?src-supplier=Compliance+Statements; ComponentLink6URL: http://sigma.octopart.com:80/reach_statement/Molex-company-74.pdf?src-supplier=Compliance+Statements; Contact Gender: Female; Contact Material: Gold, Nickel; Height: 3.36mm; LCSC PART NUMBER: C3197684; Lifecycle Status: Production; Max Operating Temperature: 85°C; Min Operating Temperature: -40°C; Number of Rows: 1; Pins: 16; Pricing: 1=0.83, 10=0.704, 25=0.6596, 50=0.628, 100=0.598, 250=0.56052, 500=0.53374 (USD); REACH SVHC: Yes; RoHS: Compliant; Shell Finish: Nickel; Shell Material: Stainless Steel; Stock: 5295; Supplier: DigiKey; Supplier Part Number: 900-2171790001CT-ND; Terminal Pitch: 500µm; USB Standard: USB 2.0; Library Name: Librayryschematic.SchLib
Q1 Q2 = SS8050-G: TRANS NPN 25V 1.5A SOT-23-3; Manufacturer: Comchip; Collector Base Voltage (VCBO): 40V; Collector Emitter Saturation Voltage: 500mV; Emitter Base Voltage (VEBO): 5V; hFE Min: 40; Max Junction Temperature (Tj): 150°C; Max Power Dissipation: 300mW; Number of Elements: 1; Transition Frequency: 100MHz; Case/Package: TO-236-3; Category: BJTs; Collector Emitter Breakdown Voltage: 25V; Collector Emitter Voltage (VCEO): 25V; Component Kind: Standard; ComponentLink1Description: https://datasheet.octopart.com:443/SS8050-G-Comchip-datasheet-180131983.pdf?src-supplier=IHS; ComponentLink1URL: https://datasheet.octopart.com:443/SS8050-G-Comchip-datasheet-180131983.pdf?src-supplier=IHS; ComponentLink2Description: https://datasheet.octopart.com:443/SS8050-G-Comchip-datasheet-155422643.pdf?src-supplier=Upverter; ComponentLink2URL: https://datasheet.octopart.com:443/SS8050-G-Comchip-datasheet-155422643.pdf?src-supplier=Upverter; ComponentLink3Description: https://datasheet.octopart.com:443/SS8050-G-Comchip-datasheet-189767092.pdf?src-supplier=ODG+(Origin+Data+Global); ComponentLink3URL: https://datasheet.octopart.com:443/SS8050-G-Comchip-datasheet-189767092.pdf?src-supplier=ODG+(Origin+Data+Global); Height: 1.15mm; LCSC PART NUMBER: C164886; Lifecycle Status: Production; Manufacturer Part Number: SS8050-G; Max Breakdown Voltage: 25V; Max Collector Current: 1.5A; Max Operating Temperature: 150°C; Mounting Technology: Surface Mount; Number of Terminals: 3; Power Dissipation: 300mW; Pricing: 1=0.24, 10=0.148, 100=0.0921, 500=0.06754, 1000=0.05958 (USD); REACH SVHC: Yes; RoHS: Compliant; Schedule B: 8541100070|8541100070|8541100070|8541100070|8541100070; Stock: 59487; Supplier: DigiKey; Supplier Part Number: 641-1790-1-ND; Library Name: Librayryschematic.SchLib
R1-R4 R7 R11 R12 = RC1206JR-1310KL: RES 10K OHM 5% 1/4W 1206; Manufacturer: Yageo Group; Manufacturer Part Number: RC0402JR-0710KL; Max Power Dissipation: 63mW; Power Dissipation: 62.5mW; Temperature Coefficient: 100ppm/°C; Value: 10kΩ; Voltage Rating: 50V; Case Code (Imperial): 0402; Case Code (Metric): 3016; Case/Package: 0402; Category: Chip SMD Resistors; China RoHS: Non-Compliant; Component Kind: Standard; ComponentLink1Description: https://datasheet.octopart.com:443/RC0402JR-0710KL-Yageo-datasheet-175887523.pdf?src-supplier=IHS; ComponentLink1URL: https://datasheet.octopart.com:443/RC0402JR-0710KL-Yageo-datasheet-175887523.pdf?src-supplier=IHS; ComponentLink2Description: https://datasheet.octopart.com:443/RC0402JR-0710KL-Yageo-datasheet-10408619.pdf?src-supplier=Newark; ComponentLink2URL: https://datasheet.octopart.com:443/RC0402JR-0710KL-Yageo-datasheet-10408619.pdf?src-supplier=Newark; ComponentLink3Description: https://datasheet.octopart.com:443/RC0402JR-0710KL-Yageo-datasheet-505231.pdf?src-supplier=Newark; ComponentLink3URL: https://datasheet.octopart.com:443/RC0402JR-0710KL-Yageo-datasheet-505231.pdf?src-supplier=Newark; ComponentLink4Description: https://datasheet.octopart.com:443/RC0402JR-0710KL-Yageo-datasheet-141265859.pdf?src-supplier=Upverter; ComponentLink4URL: https://datasheet.octopart.com:443/RC0402JR-0710KL-Yageo-datasheet-141265859.pdf?src-supplier=Upverter; ComponentLink5Description: https://sigma.octopart.com:443/138820526/technical_drawing/Yageo-RC0402JR-0710KL.pdf?src-supplier=Upverter; ComponentLink5URL: https://sigma.octopart.com:443/138820526/technical_drawing/Yageo-RC0402JR-0710KL.pdf?src-supplier=Upverter; ComponentLink6Description: https://datasheet.octopart.com:443/RC0402JR-0710KL-Yageo-datasheet-62067350.pdf?src-supplier=Farnell; ComponentLink6URL: https://datasheet.octopart.com:443/RC0402JR-0710KL-Yageo-datasheet-62067350.pdf?src-supplier=Farnell; ComponentLink7Description: https://datasheet.octopart.com:443/RC0402JR-0710KL-Yageo-datasheet-12531192.pdf?src-supplier=Seeed+Studio; ComponentLink7URL: https://datasheet.octopart.com:443/RC0402JR-0710KL-Yageo-datasheet-12531192.pdf?src-supplier=Seeed+Studio; ComponentLink8Description: https://datasheet.octopart.com:443/RC0402JR-0710KL-Yageo-datasheet-8324527.pdf?src-supplier=TME; ComponentLink8URL: https://datasheet.octopart.com:443/RC0402JR-0710KL-Yageo-datasheet-8324527.pdf?src-supplier=TME; ComponentLink9Description: https://datasheet.octopart.com:443/RC0402JR-0710KL-Yageo-datasheet-164759695.pdf?src-supplier=Future+Electronics; ComponentLink9URL: https://datasheet.octopart.com:443/RC0402JR-0710KL-Yageo-datasheet-164759695.pdf?src-supplier=Future+Electronics; ComponentLink10Description: https://datasheet.octopart.com:443/RC0402JR-0710KL-Yageo-datasheet-137700087.pdf?src-supplier=Future+Electronics; ComponentLink10URL: https://datasheet.octopart.com:443/RC0402JR-0710KL-Yageo-datasheet-137700087.pdf?src-supplier=Future+Electronics; ComponentLink11Description: https://datasheet.octopart.com/RC0402JR-0710KL-Yageo-datasheet-73766026.pdf; ComponentLink11URL: https://datasheet.octopart.com/RC0402JR-0710KL-Yageo-datasheet-73766026.pdf; ComponentLink12Description: https://datasheet.octopart.com:443/RC0402JR-0710KL-Yageo-datasheet-8329306.pdf?src-supplier=element14; ComponentLink12URL: https://datasheet.octopart.com:443/RC0402JR-0710KL-Yageo-datasheet-8329306.pdf?src-supplier=element14; ComponentLink13Description: http://sigma.octopart.com:80/rohs_statement/Yageo-company-2.pdf?src-supplier=Compliance+Statements; ComponentLink13URL: http://sigma.octopart.com:80/rohs_statement/Yageo-company-2.pdf?src-supplier=Compliance+Statements; ComponentLink14Description: http://sigma.octopart.com:80/conflict_mineral_statement/Yageo-company-3.pdf?src-supplier=Compliance+Statements; ComponentLink14URL: http://sigma.octopart.com:80/conflict_mineral_statement/Yageo-company-3.pdf?src-supplier=Compliance+Statements; ComponentLink15Description: https://datasheet.octopart.com:443/AC1206JR-0710KL-Yageo-datasheet-182189377.pdf?src-supplier=IHS; ComponentLink15URL: https://datasheet.octopart.com:443/AC1206JR-0710KL-Yageo-datasheet-182189377.pdf?src-supplier=IHS; ComponentLink16Description: https://datasheet.octopart.com:443/AC1206JR-0710KL-Yageo-datasheet-86786639.pdf?src-supplier=Upverter; ComponentLink16URL: https://datasheet.octopart.com:443/AC1206JR-0710KL-Yageo-datasheet-86786639.pdf?src-supplier=Upverter; ComponentLink17Description: https://datasheet.octopart.com:443/AC1206JR-0710KL-Yageo-datasheet-178509465.pdf?src-supplier=Newark; ComponentLink17URL: https://datasheet.octopart.com:443/AC1206JR-0710KL-Yageo-datasheet-178509465.pdf?src-supplier=Newark; ComponentLink18Description: https://datasheet.octopart.com:443/AC1206JR-0710KL-Yageo-datasheet-166720450.pdf?src-supplier=JRH+Electronics; ComponentLink18URL: https://datasheet.octopart.com:443/AC1206JR-0710KL-Yageo-datasheet-166720450.pdf?src-supplier=JRH+Electronics; ComponentLink19Description: https://datasheet.octopart.com:443/AC1206JR-0710KL-Yageo-Group-datasheet-188689268.pdf?src-supplier=Farnell; ComponentLink19URL: https://datasheet.octopart.com:443/AC1206JR-0710KL-Yageo-Group-datasheet-188689268.pdf?src-supplier=Farnell; ComponentLink20Description: https://datasheet.octopart.com:443/AC1206JR-0710KL-Yageo-Group-datasheet-164768995.pdf?src-supplier=ODG+(Origin+Data+Global); ComponentLink20URL: https://datasheet.octopart.com:443/AC1206JR-0710KL-Yageo-Group-datasheet-164768995.pdf?src-supplier=ODG+(Origin+Data+Global); ComponentLink21Description: https://datasheet.octopart.com:443/AC1206JR-0710KL-Yageo-datasheet-151884373.pdf?src-supplier=Future+Electronics; ComponentLink21URL: https://datasheet.octopart.com:443/AC1206JR-0710KL-Yageo-datasheet-151884373.pdf?src-supplier=Future+Electronics; ComponentLink22Description: https://datasheet.octopart.com:443/AC1206JR-0710KL-Yageo-datasheet-137699919.pdf?src-supplier=Future+Electronics; ComponentLink22URL: https://datasheet.octopart.com:443/AC1206JR-0710KL-Yageo-datasheet-137699919.pdf?src-supplier=Future+Electronics; ComponentLink23Description: https://datasheet.octopart.com:443/AC1206JR-0710KL-Yageo-datasheet-83209224.pdf?src-supplier=Future+Electronics; ComponentLink23URL: https://datasheet.octopart.com:443/AC1206JR-0710KL-Yageo-datasheet-83209224.pdf?src-supplier=Future+Electronics; ComponentLink24Description: https://datasheet.octopart.com:443/AC1206JR-0710KL-Yageo-datasheet-62139191.pdf?src-supplier=Future+Electronics; ComponentLink24URL: https://datasheet.octopart.com:443/AC1206JR-0710KL-Yageo-datasheet-62139191.pdf?src-supplier=Future+Electronics; ComponentLink25Description: https://datasheet.octopart.com/AC1206JR-0710KL-Yageo-datasheet-12512238.pdf; ComponentLink25URL: https://datasheet.octopart.com/AC1206JR-0710KL-Yageo-datasheet-12512238.pdf; ComponentLink26Description: https://datasheet.octopart.com:443/RC1206JR-1310KL-Yageo-datasheet-175887523.pdf?src-supplier=IHS; ComponentLink26URL: https://datasheet.octopart.com:443/RC1206JR-1310KL-Yageo-datasheet-175887523.pdf?src-supplier=IHS; ComponentLink27Description: https://datasheet.octopart.com:443/RC1206JR-1310KL-Yageo-datasheet-164759695.pdf?src-supplier=Future+Electronics; ComponentLink27URL: https://datasheet.octopart.com:443/RC1206JR-1310KL-Yageo-datasheet-164759695.pdf?src-supplier=Future+Electronics; ComponentLink28Description: https://datasheet.octopart.com:443/RC1206JR-1310KL-Yageo-datasheet-137700087.pdf?src-supplier=Future+Electronics; ComponentLink28URL: https://datasheet.octopart.com:443/RC1206JR-1310KL-Yageo-datasheet-137700087.pdf?src-supplier=Future+Electronics; ComponentLink29Description: https://datasheet.octopart.com:443/RC1206JR-1310KL-Yageo-datasheet-27233761.pdf?src-supplier=Future+Electronics; ComponentLink29URL: https://datasheet.octopart.com:443/RC1206JR-1310KL-Yageo-datasheet-27233761.pdf?src-supplier=Future+Electronics; ComponentLink30Description: https://datasheet.octopart.com:443/RC1206JR-1310KL-Yageo-datasheet-13032847.pdf?src-supplier=Future+Electronics; ComponentLink30URL: https://datasheet.octopart.com:443/RC1206JR-1310KL-Yageo-datasheet-13032847.pdf?src-supplier=Future+Electronics; ComponentLink31Description: https://datasheet.octopart.com:443/RC1206JR-1310KL-Yageo-datasheet-8359522.pdf?src-supplier=Future+Electronics; ComponentLink31URL: https://datasheet.octopart.com:443/RC1206JR-1310KL-Yageo-datasheet-8359522.pdf?src-supplier=Future+Electronics; ComponentLink32Description: https://datasheet.octopart.com/RC1206JR-1310KL-Yageo-datasheet-73766026.pdf; ComponentLink32URL: https://datasheet.octopart.com/RC1206JR-1310KL-Yageo-datasheet-73766026.pdf; Composition: Thick Film; Contact Plating: Tin; Depth: 500µm; Features: Moisture Resistant; Height: 350µm; LCSC part number: C4117928; Lead Free: Lead Free; Length: 1mm; Lifecycle Status: Production; Max Operating Temperature: 155°C; Military Standard: Not; Min Operating Temperature: -55°C; Mounting Technology: Surface Mount; Number of Terminals: 2; Number of Terminations: 2; Packaging: Tape & Reel; Pins: 2; Power Rating: 62.5mW; Pricing: 1=0.1, 10=0.006, 25=0.0056, 50=0.0052, 100=0.0046, 250=0.00416, 500=0.00284 (USD); Radiation Hardening: No; REACH SVHC: Yes; RoHS: Compliant; Schedule B: 8533210045, 8533210045|8533210045, 8533210045|8533210045|8533210045, 8533210045|8533210045|8533210045|8533210045; Stock: 11539169; Supplier: DigiKey; Supplier Part Number: 311-10KJRCT-ND; Termination: SMD/SMT; Tolerance: 5%; Voltage Rating (AC): 50V; Voltage Rating (DC): 50V; Width: 500µm; Working Voltage: 50V; Library Name: Librayryschematic.SchLib
R5 R6 = RC1206FR-075K1L: RES 5.1K OHM 1% 1/4W 1206; Manufacturer: Yageo Group; Manufacturer Part Number: RC0402FR-075K1L; Max Power Dissipation: 63mW; Power Dissipation: 62.5mW; Temperature Coefficient: 100ppm/°C; Value: 5.1kΩ; Voltage Rating: 50V; Case Code (Imperial): 0402; Case/Package: 0402; Category: Chip SMD Resistors; China RoHS: Non-Compliant; Component Kind: Standard; ComponentLink1Description: https://datasheet.octopart.com:443/RC0402FR-075K1L-Yageo-datasheet-175887523.pdf?src-supplier=IHS; ComponentLink1URL: https://datasheet.octopart.com:443/RC0402FR-075K1L-Yageo-datasheet-175887523.pdf?src-supplier=IHS; ComponentLink2Description: https://datasheet.octopart.com:443/RC0402FR-075K1L-Yageo-datasheet-103092701.pdf?src-supplier=Upverter; ComponentLink2URL: https://datasheet.octopart.com:443/RC0402FR-075K1L-Yageo-datasheet-103092701.pdf?src-supplier=Upverter; ComponentLink3Description: https://datasheet.octopart.com:443/RC0402FR-075K1L-Yageo-datasheet-10408619.pdf?src-supplier=Newark; ComponentLink3URL: https://datasheet.octopart.com:443/RC0402FR-075K1L-Yageo-datasheet-10408619.pdf?src-supplier=Newark; ComponentLink4Description: https://datasheet.octopart.com:443/RC0402FR-075K1L-Yageo-datasheet-8324527.pdf?src-supplier=Newark; ComponentLink4URL: https://datasheet.octopart.com:443/RC0402FR-075K1L-Yageo-datasheet-8324527.pdf?src-supplier=Newark; ComponentLink5Description: https://datasheet.octopart.com:443/RC0402FR-075K1L-Yageo-datasheet-62067350.pdf?src-supplier=element14+APAC; ComponentLink5URL: https://datasheet.octopart.com:443/RC0402FR-075K1L-Yageo-datasheet-62067350.pdf?src-supplier=element14+APAC; ComponentLink6Description: https://datasheet.octopart.com:443/RC0402FR-075K1L-Yageo-datasheet-164759695.pdf?src-supplier=Future+Electronics; ComponentLink6URL: https://datasheet.octopart.com:443/RC0402FR-075K1L-Yageo-datasheet-164759695.pdf?src-supplier=Future+Electronics; ComponentLink7Description: https://datasheet.octopart.com:443/RC0402FR-075K1L-Yageo-datasheet-137700087.pdf?src-supplier=Future+Electronics; ComponentLink7URL: https://datasheet.octopart.com:443/RC0402FR-075K1L-Yageo-datasheet-137700087.pdf?src-supplier=Future+Electronics; ComponentLink8Description: https://datasheet.octopart.com:443/RC0402FR-075K1L-Yageo-datasheet-12531192.pdf?src-supplier=Future+Electronics; ComponentLink8URL: https://datasheet.octopart.com:443/RC0402FR-075K1L-Yageo-datasheet-12531192.pdf?src-supplier=Future+Electronics; ComponentLink9Description: https://datasheet.octopart.com:443/RC0402FR-075K1L-Yageo-datasheet-505231.pdf?src-supplier=Future+Electronics; ComponentLink9URL: https://datasheet.octopart.com:443/RC0402FR-075K1L-Yageo-datasheet-505231.pdf?src-supplier=Future+Electronics; ComponentLink10Description: https://datasheet.octopart.com:443/RC0402FR-075K1L-Yageo-datasheet-148775077.pdf?src-supplier=TME; ComponentLink10URL: https://datasheet.octopart.com:443/RC0402FR-075K1L-Yageo-datasheet-148775077.pdf?src-supplier=TME; ComponentLink11Description: https://datasheet.octopart.com/RC0402FR-075K1L-Yageo-datasheet-73766026.pdf; ComponentLink11URL: https://datasheet.octopart.com/RC0402FR-075K1L-Yageo-datasheet-73766026.pdf; ComponentLink12Description: https://datasheet.octopart.com:443/RC0402FR-075K1L-Yageo-datasheet-8329306.pdf?src-supplier=element14; ComponentLink12URL: https://datasheet.octopart.com:443/RC0402FR-075K1L-Yageo-datasheet-8329306.pdf?src-supplier=element14; ComponentLink13Description: http://sigma.octopart.com:80/rohs_statement/Yageo-company-2.pdf?src-supplier=Compliance+Statements; ComponentLink13URL: http://sigma.octopart.com:80/rohs_statement/Yageo-company-2.pdf?src-supplier=Compliance+Statements; ComponentLink14Description: http://sigma.octopart.com:80/conflict_mineral_statement/Yageo-company-3.pdf?src-supplier=Compliance+Statements; ComponentLink14URL: http://sigma.octopart.com:80/conflict_mineral_statement/Yageo-company-3.pdf?src-supplier=Compliance+Statements; Composition: Thick Film; Depth: 500µm; Features: Moisture Resistant; Height: 350µm; LCSC PART NUMBER: C163372; Lead Free: Lead Free; Length: 1mm; Lifecycle Status: Production; Max Operating Temperature: 155°C; Military Standard: Not; Min Operating Temperature: -55°C; Mounting Technology: Surface Mount; Number of Terminals: 2; Number of Terminations: 2; Packaging: Cut Tape; Pins: 2; Power Rating: 63mW; Pricing: 1=0.1, 10=0.008, 25=0.0072, 50=0.0062, 100=0.0056, 250=0.00308 (USD); REACH SVHC: Yes; RoHS: Compliant; Schedule B: 8533210045, 8533210045|8533210045, 8533210045|8533210045|8533210045, 8533210045|8533210045|8533210045|8533210045; Stock: 251126; Supplier: DigiKey; Supplier Part Number: 311-5.10KLRCT-ND; Termination: SMD/SMT; Tolerance: 1%; Voltage Rating (DC): 50V; Width: 500µm; Working Voltage: 50V; Library Name: Librayryschematic.SchLib
R8 = RC1206FR-0722K1L: RES 22.1K OHM 1% 1/4W 1206; Manufacturer: Yageo Group; Manufacturer Part Number: AC0402FR-0722K1L; Max Power Dissipation: 63mW; Power Dissipation: 62.5mW; Temperature Coefficient: 100ppm/°C; Value: 22.1kΩ; Voltage Rating: 50V; Case Code (Imperial): 0402; Case Code (Metric): 1005; Case/Package: 0402; Category: Chip SMD Resistors; China RoHS: Non-Compliant; Component Kind: Standard; ComponentLink1Description: https://datasheet.octopart.com:443/AC0402FR-0722K1L-Yageo-datasheet-182189377.pdf?src-supplier=IHS; ComponentLink1URL: https://datasheet.octopart.com:443/AC0402FR-0722K1L-Yageo-datasheet-182189377.pdf?src-supplier=IHS; ComponentLink2Description: https://datasheet.octopart.com:443/AC0402FR-0722K1L-Yageo-datasheet-83209224.pdf?src-supplier=Upverter; ComponentLink2URL: https://datasheet.octopart.com:443/AC0402FR-0722K1L-Yageo-datasheet-83209224.pdf?src-supplier=Upverter; ComponentLink3Description: https://datasheet.octopart.com:443/AC0402FR-0722K1L-Yageo-datasheet-178509465.pdf?src-supplier=Newark; ComponentLink3URL: https://datasheet.octopart.com:443/AC0402FR-0722K1L-Yageo-datasheet-178509465.pdf?src-supplier=Newark; ComponentLink4Description: https://datasheet.octopart.com:443/AC0402FR-0722K1L-Yageo-datasheet-166720450.pdf?src-supplier=Future+Electronics; ComponentLink4URL: https://datasheet.octopart.com:443/AC0402FR-0722K1L-Yageo-datasheet-166720450.pdf?src-supplier=Future+Electronics; ComponentLink5Description: https://datasheet.octopart.com:443/AC0402FR-0722K1L-Yageo-datasheet-151884373.pdf?src-supplier=Future+Electronics; ComponentLink5URL: https://datasheet.octopart.com:443/AC0402FR-0722K1L-Yageo-datasheet-151884373.pdf?src-supplier=Future+Electronics; ComponentLink6Description: https://datasheet.octopart.com:443/AC0402FR-0722K1L-Yageo-datasheet-137699919.pdf?src-supplier=Future+Electronics; ComponentLink6URL: https://datasheet.octopart.com:443/AC0402FR-0722K1L-Yageo-datasheet-137699919.pdf?src-supplier=Future+Electronics; ComponentLink7Description: https://datasheet.octopart.com:443/AC0402FR-0722K1L-Yageo-datasheet-86786639.pdf?src-supplier=Future+Electronics; ComponentLink7URL: https://datasheet.octopart.com:443/AC0402FR-0722K1L-Yageo-datasheet-86786639.pdf?src-supplier=Future+Electronics; ComponentLink8Description: https://datasheet.octopart.com:443/AC0402FR-0722K1L-Yageo-datasheet-128677973.pdf?src-supplier=X-ON; ComponentLink8URL: https://datasheet.octopart.com:443/AC0402FR-0722K1L-Yageo-datasheet-128677973.pdf?src-supplier=X-ON; ComponentLink9Description: https://datasheet.octopart.com/AC0402FR-0722K1L-Yageo-datasheet-12512238.pdf; ComponentLink9URL: https://datasheet.octopart.com/AC0402FR-0722K1L-Yageo-datasheet-12512238.pdf; ComponentLink10Description: http://sigma.octopart.com:80/rohs_statement/Yageo-company-2.pdf?src-supplier=Compliance+Statements; ComponentLink10URL: http://sigma.octopart.com:80/rohs_statement/Yageo-company-2.pdf?src-supplier=Compliance+Statements; ComponentLink11Description: http://sigma.octopart.com:80/conflict_mineral_statement/Yageo-company-3.pdf?src-supplier=Compliance+Statements; ComponentLink11URL: http://sigma.octopart.com:80/conflict_mineral_statement/Yageo-company-3.pdf?src-supplier=Compliance+Statements; Composition: Thick Film; Features: Automotive AEC-Q200, Moisture Resistant; Height: 320µm; LCSC PART NUMBER: C488737; Length: 990.6µm; Lifecycle Status: Production; Max Operating Temperature: 155°C; Min Operating Temperature: -55°C; Mounting Technology: Surface Mount; Number of Terminals: 2; Number of Terminations: 2; Packaging: Tape & Reel; Power Rating: 62.5mW; Pricing: 1=0.1, 10=0.009, 25=0.008, 50=0.0072, 100=0.0066, 250=0.00408, 500=0.00406 (USD); REACH SVHC: Yes; RoHS: Compliant; Schedule B: 8533210045, 8533210045|8533210045|8533210045|8533210045|8533210045; Stock: 23159; Supplier: DigiKey; Supplier Part Number: YAG3457CT-ND; Tolerance: 1%; Width: 500µm; Working Voltage: 50V; Library Name: Librayryschematic.SchLib
